# Altium schematic sheet [15] PWR.SchDoc("[15] PWR")
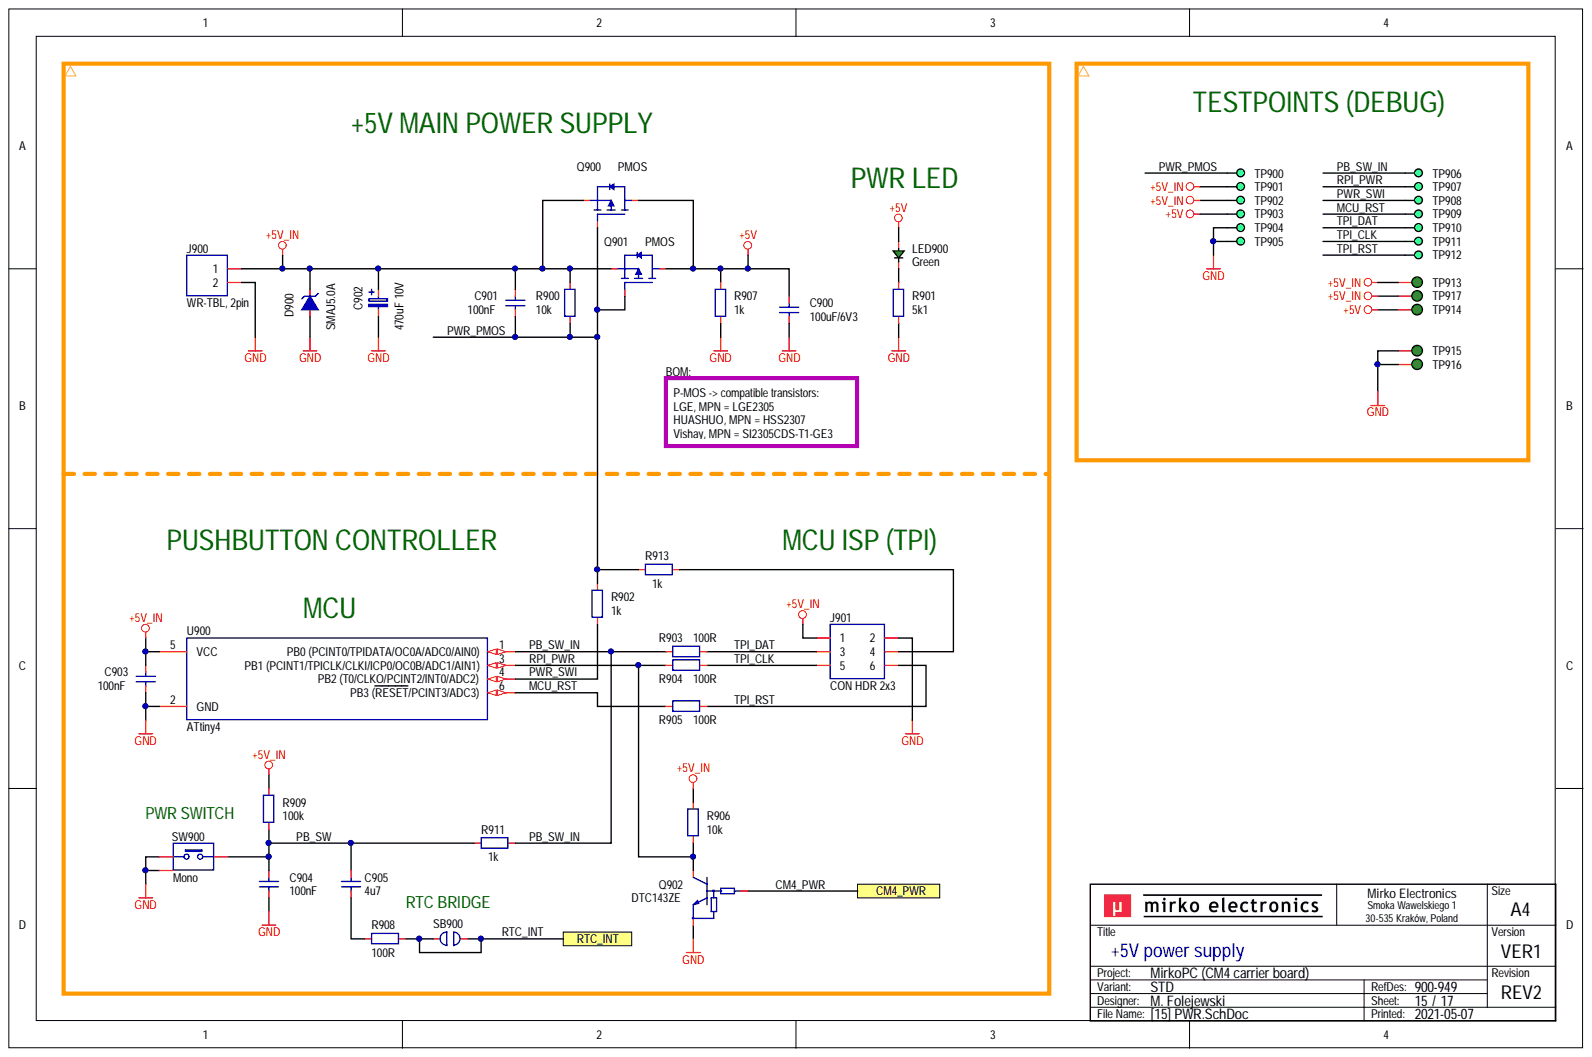
[diagram p0: [15] PWR.SchDoc]

## Parts
C900  100uF/6V3  [CAP_1206_R]
C901  100nF  [CAP_0603_R]
C902  470uF 10V  [CAP_RAD_D630H1100P250_R]
C903  100nF  [CAP_0603_R]
C904  100nF  [CAP_0603_R]
C905  4u7  [CAP_0603_R]
D900  SMAJ5.0A  [DIO_SMA_UNI_R]
J900  WR-TBL, 2pin  [CON_WRT_691305140002]
J901  CON HDR 2x3  [CON_HDR_P200_2X03_STR]
LED900  Green  [LED_0805_GRN_R]
Q900  PMOS  [PKG_SOT23-3_TO236]
Q901  PMOS  [PKG_SOT23-3_TO236]
Q902  DTC143ZE  [PKG_SOT523_SC75_SOT416_P100_R]
R900  10k  [RES_0603_R]
R901  5k1  [RES_0603_R]
R902  1k  [RES_0603_R]
R903  100R  [RES_0603_R]
R904  100R  [RES_0603_R]
R905  100R  [RES_0603_R]
R906  10k  [RES_0603_R]
R907  1k  [RES_0603_R]
R908  100R  [RES_0603_R]
R909  100k  [RES_0603_R]
R911  1k  [RES_0603_R]
R913  1k  [RES_0603_R]
SB900  ?  (value not drawn)  (no BOM)
SW900  Mono  [SWI_XKB_TC-1109DE-C-J]
TP900  DEBUG  [PCB_TP_DBG_50]  (value not drawn)  (no BOM)
TP901  DEBUG  [PCB_TP_DBG_50]  (value not drawn)  (no BOM)
TP902  DEBUG  [PCB_TP_DBG_50]  (value not drawn)  (no BOM)
TP903  DEBUG  [PCB_TP_DBG_50]  (value not drawn)  (no BOM)
TP904  DEBUG  [PCB_TP_DBG_50]  (value not drawn)  (no BOM)
TP905  DEBUG  [PCB_TP_DBG_50]  (value not drawn)  (no BOM)
TP906  DEBUG  [PCB_TP_DBG_50]  (value not drawn)  (no BOM)
TP907  DEBUG  [PCB_TP_DBG_50]  (value not drawn)  (no BOM)
TP908  DEBUG  [PCB_TP_DBG_50]  (value not drawn)  (no BOM)
TP909  DEBUG  [PCB_TP_DBG_50]  (value not drawn)  (no BOM)
TP910  DEBUG  [PCB_TP_DBG_50]  (value not drawn)  (no BOM)
TP911  DEBUG  [PCB_TP_DBG_50]  (value not drawn)  (no BOM)
TP912  DEBUG  [PCB_TP_DBG_50]  (value not drawn)  (no BOM)
TP913  ICT  [PCB_TP_ICT_100]  (value not drawn)  (no BOM)
TP914  ICT  [PCB_TP_ICT_100]  (value not drawn)  (no BOM)
TP915  ICT  [PCB_TP_ICT_100]  (value not drawn)  (no BOM)
TP916  ICT  [PCB_TP_ICT_100]  (value not drawn)  (no BOM)
TP917  ICT  [PCB_TP_ICT_100]  (value not drawn)  (no BOM)
U900  ATtiny4  Component Subtype: MCU  [PKG_SOT23-6]

## Ports
CM4_PWR, RTC_INT

## Nets
+5V: C900.2 LED900.2 Q900.3 Q901.3 R907.1 TP903.1 TP914.1
+5V_IN: C901.2 C902.2 C903.2 D900.1 J900.1 J901.1 Q900.2 Q901.2 R900.2 R906.2 R909.2 TP901.1 TP902.1 TP913.1 TP917.1 U900.5(VCC)
GND: C900.1 C902.1 C903.1 C904.1 D900.2 J900.2 J901.2 Q902.2 R901.2 R907.2 SW900.1 SW900.3 TP904.1 TP905.1 TP915.1 TP916.1 U900.2(GND)
CM4_PWR: Q902.1 ; port CM4_PWR
MCU_RST: R905.1 TP909.1 U900.6(PB3_(RESET/PCINT3/ADC3))
PB_SW: C904.2 C905.2 R909.1 R911.1 SW900.2
PB_SW_IN: R903.1 R911.2 TP906.1 U900.1
PWR_PMOS: C901.1 Q900.1 Q901.1 R900.1 R902.2 R913.2 TP900.1
PWR_SWI: R902.1 TP908.1 U900.4(PB2_(T0/CLKO/PCINT2/INT0/ADC2))
RPI_PWR: Q902.3 R904.1 R906.1 TP907.1 U900.3(PB1_(PCINT1/TPICLK/CLKI/ICP0/OC0B/ADC1/AIN1))
RTC_INT: R908.2 SB900.1 SB900.2 ; port RTC_INT
TPI_CLK: J901.5 R904.2 TP911.1
TPI_DAT: J901.3 R903.2 TP910.1
TPI_RST: J901.6 R905.2 TP912.1
NetC905_1: C905.1 R908.1
NetJ901_4: J901.4 R913.1
NetLED900_1: LED900.1 R901.1

## Library data of the parts
C900 = CAP-0025: Capacitor; MLCC; GENERIC; TBD; TBD; TBD; TBD; SMD; 1206 (3216);; Database Table Name: CAPACITOR$; Value: 100uF/6V3; Supplier: ?; Package (Case): 1206 (3216); MPN: GENERIC; Mounting Type: SMD; Manufacturer: GENERIC; Component Type: Capacitor; Component Subtype: MLCC; Component Kind: Standard; Library Name: MirFol_db_local.DbLib
C901 C903 C904 = CAP-0008: Capacitor; MLCC; 100nF; TBD; TBD; TBD; TBD; SMD; 0603 (1608);; Database Table Name: CAPACITOR$; Value: 100nF; Supplier: ?; Package (Case): 0603 (1608); MPN: TBD; Mounting Type: SMD; Manufacturer: TBD; Component Type: Capacitor; Component Subtype: MLCC; Component Kind: Standard; Library Name: MirFol_db_local.DbLib
C902 = CAP_POL_2P_NP: Capacitor; Polarized;; MPN: 10ZLQ470MEFC6.3X11; Manufacturer: Rubycon; Package (Case): THT (6.3x11mm); Component Subtype: Electrolytic; Value: 470uF 10V; Supplier: LCSC; Mounting Type: THT; Component Type: Capacitor; Component Kind: Standard; Library Name: MirFol_lib.SchLib
C905 = CAP-0023: Capacitor; MLCC; GENERIC; TBD; TBD; TBD; TBD; SMD; 0603 (1608);; Database Table Name: CAPACITOR$; Value: 4u7; Supplier: ?; Package (Case): 0603 (1608); MPN: GENERIC; Mounting Type: SMD; Manufacturer: GENERIC; Component Type: Capacitor; Component Subtype: MLCC; Component Kind: Standard; Library Name: MirFol_db_local.DbLib
D900 = DIO_TVS_UNI_KA: Diode; Schottky; Unipolar;; Manufacturer: Littelfuse; Component Subtype: TVS Diode; Value: SMAJ5.0A; Supplier: ?; MPN: SMAJ5.0A; Mounting Type: SMD; Component Type: Diode; Component Kind: Standard; Library Name: MirFol_lib.SchLib
J900 = CON_1X02_COL: Connector; 1 Row;; MPN: 691 305 140 002; Manufacturer: Wurth Elektronik; Component Subtype: Terminal Block; Value: WR-TBL, 2pin; Supplier: ?; Mounting Type: SMD; Component Type: Connector; Component Kind: Standard; Library Name: MirFol_lib.SchLib
J901 = CON_2X03_ROW: Connector; 2 Row;; Package (Case): THT; Component Subtype: Pin Header; Value: CON HDR 2x3; Mounting Type: THT; Component Type: Connector; Component Kind: Standard; Library Name: MirFol_lib.SchLib
LED900 = DIO_LED_GRN: Diode; LED; Green;; MPN: NCD0805G1; Manufacturer: Foshan NationStar Optoelectronics; Package (Case): 0805 (2012); Component Subtype: LED Diode; Value: Green; Supplier: LCSC; Mounting Type: SMD; Component Type: LED; Component Kind: Standard; Library Name: MirFol_lib.SchLib
Q900 Q901 = TRA_PMOS_GSD: Transistor; PMOS;; MPN: SI2305CDS-T1-GE3; Manufacturer: Vishay; Component Subtype: P-MOSFET; Value: PMOS; Supplier: ?; Mounting Type: SMD; Component Type: Transistor; Component Kind: Standard; Library Name: MirFol_lib.SchLib
Q902 = TRA_BJT_NPN_DIG_BEC: Transistor; BJT; NPN; Digital;; Component Subtype: NPN Transistor; Value: DTC143ZE; Supplier: ?; Mounting Type: SMD; Component Type: Transistor; Component Kind: Standard; Library Name: MirFol_lib.SchLib
R900 R906 = RES-0028: Resistor; Thick Film; 10k; 1%; 100mW; 100PPM; 75V; Standard; SMD; 0603 (1608);; MPN: RC0603FR-0710KL; Manufacturer: Yageo Corporation; Database Table Name: RESISTOR$; Value: 10k; Supplier: ?; Package (Case): 0603 (1608); Mounting Type: SMD; Component Type: Resistor; Component Subtype: Thick Film; Component Kind: Standard; Library Name: MirFol_db_local.DbLib
R901 = RES-0043: Resistor; Thick Film; 5k1; 1%; 100mW; 100PPM; 75V; Standard; SMD; 0603 (1608);; MPN: TBD; Manufacturer: Yageo Corporation; Database Table Name: RESISTOR$; Value: 5k1; Supplier: ?; Package (Case): 0603 (1608); Mounting Type: SMD; Component Type: Resistor; Component Subtype: Thick Film; Component Kind: Standard; Library Name: MirFol_db_local.DbLib
R902 R907 R911 R913 = RES-0027: Resistor; Thick Film; 1k; 1%; 100mW; 100PPM; 75V; Standard; SMD; 0603 (1608);; MPN: TBD; Manufacturer: Yageo Corporation; Database Table Name: RESISTOR$; Value: 1k; Supplier: ?; Package (Case): 0603 (1608); Mounting Type: SMD; Component Type: Resistor; Component Subtype: Thick Film; Component Kind: Standard; Library Name: MirFol_db_local.DbLib
R903-R905 R908 = RES-0026: Resistor; Thick Film; 100R; 1%; 100mW; 100PPM; 75V; Standard; SMD; 0603 (1608);; MPN: TBD; Manufacturer: Yageo Corporation; Database Table Name: RESISTOR$; Value: 100R; Supplier: ?; Package (Case): 0603 (1608); Mounting Type: SMD; Component Type: Resistor; Component Subtype: Thick Film; Component Kind: Standard; Library Name: MirFol_db_local.DbLib
R909 = RES-0029: Resistor; Thick Film; 100k; 1%; 100mW; 100PPM; 75V; Standard; SMD; 0603 (1608);; MPN: TBD; Manufacturer: Yageo Corporation; Database Table Name: RESISTOR$; Value: 100k; Supplier: ?; Package (Case): 0603 (1608); Mounting Type: SMD; Component Type: Resistor; Component Subtype: Thick Film; Component Kind: Standard; Library Name: MirFol_db_local.DbLib
SB900 = PCB_SB_2P: PCB; Solder bridge; SMD;; Library Name: MirFol_lib.SchLib
SW900 = SWI_TACT_2P_SH1: Switch; Tactile; Monostable; Shielded;; MPN: TC-1109DE-C-J; Manufacturer: XKB Connectivity; Value: Mono; Package: SMD; Mounting Type: SMD; Component Type: Switch; Component Kind: Standard; Library Name: MirFol_lib.SchLib
TP900-TP912 = PCB_TP_DBG: PCB Marking; Test Point (Debug);; Value: DEBUG; Supplier: ?; Mounting Type: -; Component Type: Testpoint; Component Subtype: -; Library Name: MirFol_lib.SchLib
TP913-TP917 = PCB_TP_ICT: Test Point (ICT); Specification: Testpoint (for ICT), SMD pad; Value: ICT; MPN: -; Mounting Type: Non component; Manufacturer: -; Component Type: Testpoint (for ICT); Library Name: MirFol_lib.SchLib
U900 = ICC_MCP_ATTINY4_5_8_9_SOT-23: ICC; MCU;; MPN: ATTINY4-TSHR; Manufacturer: Microchip Technology; Package (Case): SOT23-6; Value: ATtiny4; Mounting Type: SMD; Component Type: IC; Component Kind: Standard; Library Name: MirFol_lib.SchLib
